# Altium schematic sheet RH850_F1L_revB.SchDoc(RH850_F1L_revB)
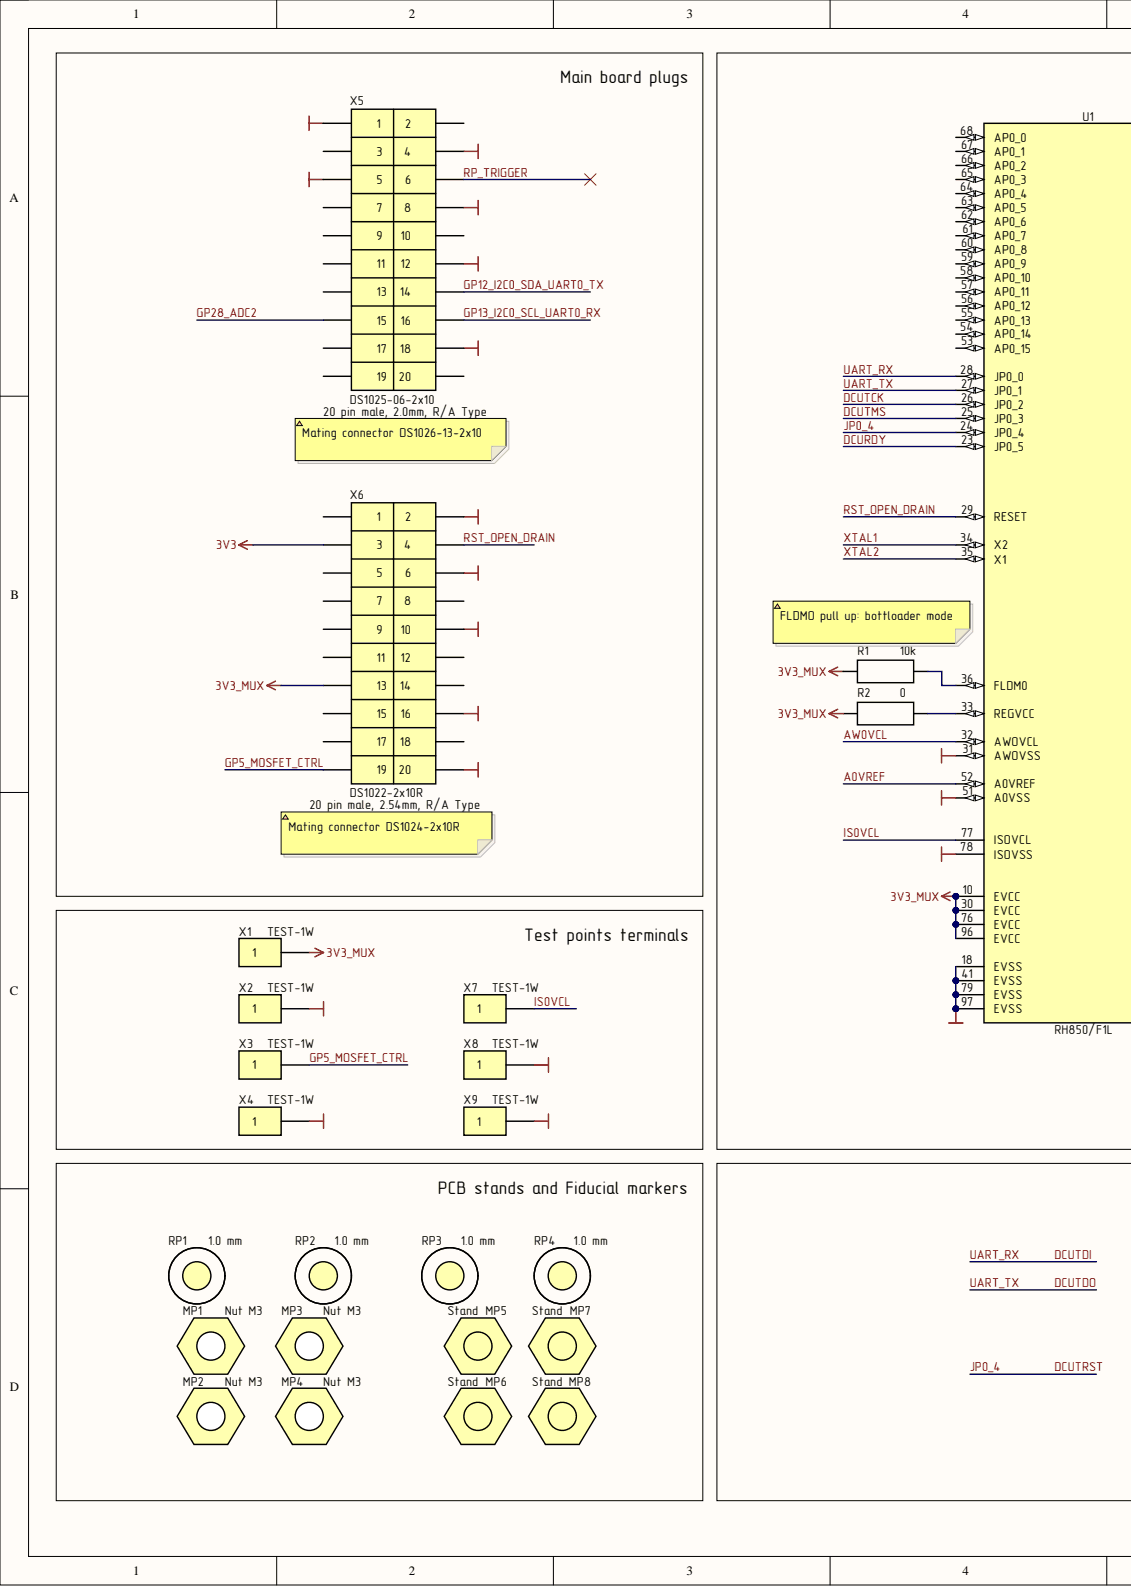
[diagram p0: RH850_F1L_revB.SchDoc, left]
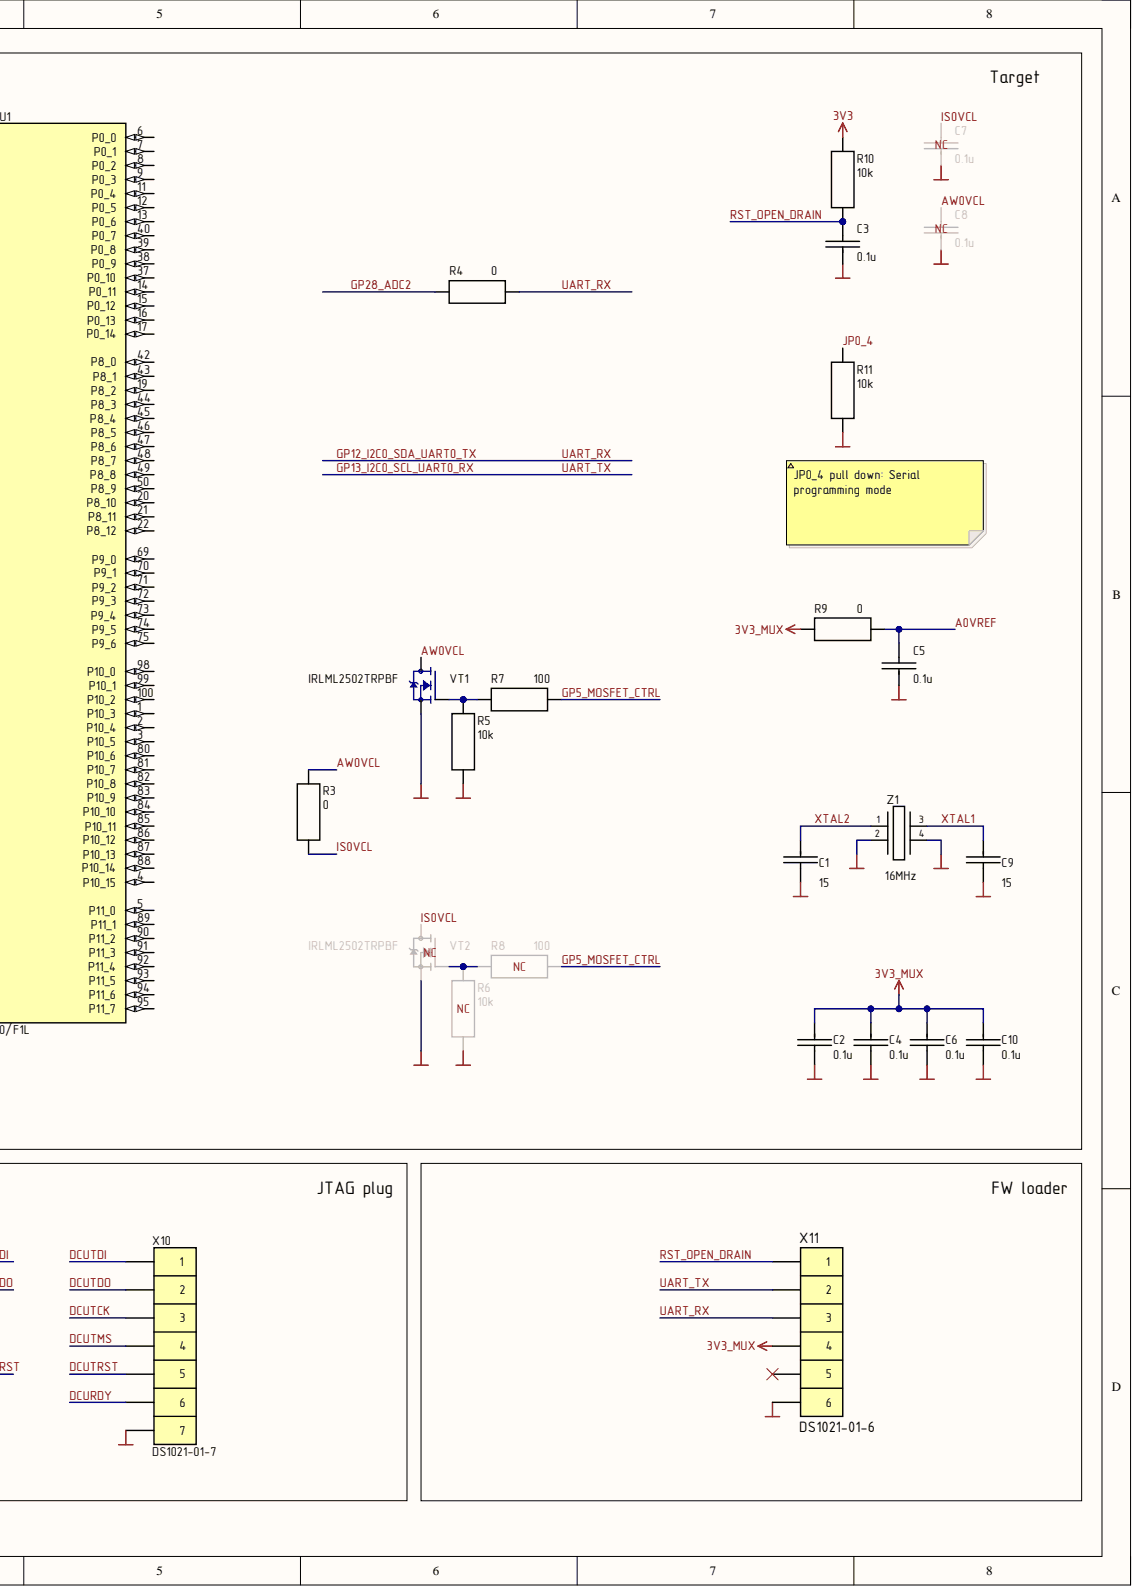
[diagram p1: RH850_F1L_revB.SchDoc, right]

## Parts
C1  value 15  [CH0603C]  (hidden comment: Ceramic capacitor, 0603, 15, ±10%, 100V, C0G, -55...+125°C)
C2  value 0.1u  [CH0603C]  (hidden comment: Ceramic capacitor, 0603, 0.1u, ±10%, 50V, X7R, -55...+125°C)
C3  value 0.1u  [CH0603C]  (hidden comment: Ceramic capacitor, 0603, 0.1u, ±10%, 50V, X7R, -55...+125°C)
C4  value 0.1u  [CH0603C]  (hidden comment: Ceramic capacitor, 0603, 0.1u, ±10%, 50V, X7R, -55...+125°C)
C5  value 0.1u  [CH0603C]  (hidden comment: Ceramic capacitor, 0603, 0.1u, ±10%, 50V, X7R, -55...+125°C)
C6  value 0.1u  [CH0603C]  (hidden comment: Ceramic capacitor, 0603, 0.1u, ±10%, 50V, X7R, -55...+125°C)
C7  value 0.1u  [CH0603C]  (hidden comment: Ceramic capacitor, 0603, 0.1u, ±10%, 50V, X7R, -55...+125°C)
C8  value 0.1u  [CH0603C]  (hidden comment: Ceramic capacitor, 0603, 0.1u, ±10%, 50V, X7R, -55...+125°C)
C9  value 15  [CH0603C]  (hidden comment: Ceramic capacitor, 0603, 15, ±10%, 100V, C0G, -55...+125°C)
C10  value 0.1u  [CH0603C]  (hidden comment: Ceramic capacitor, 0603, 0.1u, ±10%, 50V, X7R, -55...+125°C)
MP1  Nut M3  [Stand]  (mechanical)
MP2  Nut M3  [Stand]  (mechanical)
MP3  Nut M3  [Stand]  (mechanical)
MP4  Nut M3  [Stand]  (mechanical)
MP5  Stand  [Stand]
MP6  Stand  [Stand]
MP7  Stand  [Stand]
MP8  Stand  [Stand]
R1  value 10k  [CH0603R]  (hidden comment: Resistor thick-film, 0603, 10k, ±5%, 0.1W, -55...+125°C)
R2  value 0  [CH0603R]  (hidden comment: Resistor thick-film, 0603, 0, ±5%, 0.1W, -55...+125°C)
R3  value 0  [CH0603R]  (hidden comment: Resistor thick-film, 0603, 0, ±5%, 0.1W, -55...+125°C)
R4  value 0  [CH0603R]  (hidden comment: Resistor thick-film, 0603, 0, ±5%, 0.1W, -55...+125°C)
R5  value 10k  [CH0603R]  (hidden comment: Resistor thick-film, 0603, 10k, ±5%, 0.1W, -55...+125°C)
R6  value 10k  [CH0603R]  (hidden comment: Resistor thick-film, 0603, 10k, ±5%, 0.1W, -55...+125°C)
R7  value 100  [CH0603R]  (hidden comment: Resistor thick-film, 0603, 100, ±5%, 0.1W, -55...+125°C)
R8  value 100  [CH0603R]  (hidden comment: Resistor thick-film, 0603, 100, ±5%, 0.1W, -55...+125°C)
R9  value 0  [CH0603R]  (hidden comment: Resistor thick-film, 0603, 0, ±5%, 0.1W, -55...+125°C)
R10  value 10k  [CH0603R]  (hidden comment: Resistor thick-film, 0603, 10k, ±5%, 0.1W, -55...+125°C)
R11  value 10k  [CH0603R]  (hidden comment: Resistor thick-film, 0603, 10k, ±5%, 0.1W, -55...+125°C)
RP1  1.0 mm  [REPER-10]  (no BOM)
RP2  1.0 mm  [REPER-10]  (no BOM)
RP3  1.0 mm  [REPER-10]  (no BOM)
RP4  1.0 mm  [REPER-10]  (no BOM)
U1  RH850/F1L  [LQFP100-0.5-13.8X13.8MM]
VT1  IRLML2502TRPBF  [SOT23]
VT2  IRLML2502TRPBF  [SOT23]
X1  PartNumber: TEST-1W  [TEST-1W 1pin MULTICOMP]  (hidden comment: VDD)
X2  PartNumber: TEST-1W  [TEST-1W 1pin MULTICOMP]  (hidden comment: GND)
X3  GP5  PartNumber: TEST-1W  [TEST-1W 1pin MULTICOMP]
X4  PartNumber: TEST-1W  [TEST-1W 1pin MULTICOMP]  (hidden comment: GND)
X5  DS1025-06-2x10  [DS1025-06-2x10]
X6  DS1022-2x10R  [DS1022-2x10R]
X7  PartNumber: TEST-1W  [TEST-1W 1pin MULTICOMP]  (hidden comment: IS0VCl)
X8  PartNumber: TEST-1W  [TEST-1W 1pin MULTICOMP]  (hidden comment: GND)
X9  PartNumber: TEST-1W  [TEST-1W 1pin MULTICOMP]  (hidden comment: GND)
X10  DS1021-01-7  [DS1021-01-7 2.54]
X11  DS1021-01-6  [DS1021-01-6  2.54]
Z1  16MHz  [OSC: 3225]

## Nets
3V3: R10.2 X6.3
3V3_MUX: C2.1 C4.1 C6.1 C10.1 R1.2 R2.2 R9.2 U1.10(EVCC) U1.30(EVCC) U1.76(EVCC) U1.96(EVCC) X1.1 X6.13 X11.4
GND: C1.2 C2.2 C3.1 C4.2 C5.1 C6.2 C7.1 C8.1 C9.2 C10.2 R5.1 R6.1 R11.1 U1.18(EVSS) U1.31(AWOVSS) U1.41(EVSS) U1.51(A0VSS) U1.78(ISOVSS) U1.79(EVSS) U1.97(EVSS) VT1.2 VT2.2 X2.1 X4.1 X5.1 X5.4 X5.5 X5.8 X5.12 X5.18 X6.2 X6.6 X6.10 X6.16 X6.20 X8.1 X9.1 X10.7 X11.6 Z1.2 Z1.4
A0VREF: C5.2 R9.1 U1.52(A0VREF)
AW0VCL: C8.2 R3.2 U1.32(AWOVCL) VT1.3
DCURDY: U1.23(JP0_5) X10.6
DCUTCK: U1.26(JP0_2) X10.3
DCUTDI: R4.1 U1.28(JP0_0) X5.14 X10.1 X11.3
DCUTDO: U1.27(JP0_1) X5.16 X10.2 X11.2
DCUTMS: U1.25(JP0_3) X10.4
DCUTRST: R11.2 U1.24(JP0_4) X10.5
GP5_MOSFET_CTRL: R7.1 R8.1 X3.1 X6.19
GP28_ADC2: R4.2 X5.15
IS0VCL: C7.2 R3.1 U1.77(ISOVCL) VT2.3 X7.1
RP_TRIGGER: X5.6
RST_OPEN_DRAIN: C3.2 R10.1 U1.29(RESET) X6.4 X11.1
XTAL1: C9.1 U1.34(X2) Z1.3
XTAL2: C1.1 U1.35(X1) Z1.1
NetR1_1: R1.1 U1.36(FLDM0)
NetR2_1: R2.1 U1.33(REGVCC)
NetR5_2: R5.2 R7.2 VT1.1
NetR6_2: R6.2 R8.2 VT2.1

Not connected: MP5 1; MP6 1; MP7 1; MP8 1; RP1 1; RP2 1; RP3 1; RP4 1; U1 1(P10_3) 2(P10_4) 3(P10_5) 4(P10_15) 5(P11_0) 6(P0_0) 7(P0_1) 8(P0_2) 9(P0_3) 11(P0_4) 12(P0_5) 13(P0_6) 14(P0_11) 15(P0_12) 16(P0_13) 17(P0_14) 19(P8_2) 20(P8_10) 21(P8_11) 22(P8_12) 37(P0_10) 38(P0_9) 39(P0_8) 40(P0_7) 42(P8_0) 43(P8_1) 44(P8_3) 45(P8_4) 46(P8_5) 47(P8_6) 48(P8_7) 49(P8_8) 50(P8_9) 53(AP0_15) 54(AP0_14) 55(AP0_13) 56(AP0_12) 57(AP0_11) 58(AP0_10) 59(AP0_9) 60(AP0_8) 61(AP0_7) 62(AP0_6) 63(AP0_5) 64(AP0_4) 65(AP0_3) 66(AP0_2) 67(AP0_1) 68(AP0_0) 69(P9_0) 70(P9_1) 71(P9_2) 72(P9_3) 73(P9_4) 74(P9_5) 75(P9_6) 80(P10_6) 81(P10_7) 82(P10_8) 83(P10_9) 84(P10_10) 85(P10_11) 86(P10_12) 87(P10_13) 88(P10_14) 89(P11_1) 90(P11_2) 91(P11_3) 92(P11_4) 93(P11_5) 94(P11_6) 95(P11_7) 98(P10_0) 99(P10_1) 100(P10_2); X5 2 3 7 9 10 11 13 17 19 20; X6 1 5 7 8 9 11 12 14 15 17 18; X11 5

## Library data of the parts
C1 C9 = Capacitor: Manufacturer: Murata; PartNumber: GRM1885C2A150J; Type: SMD; Component Kind: Standard; ComponentType: Ceramic capacitor; Description/Value: Ceramic capacitor, 0603, 15, ±10%, 100V, C0G, -55...+125°C; HelpURL: ?; Library Name: Common Lib.SchLib; Package: 0603; Temperature: -55...+125°C; Tolerance: ±10%; TypeDielectric: C0G; Voltage: 100V
C2-C8 C10 = Capacitor: Manufacturer: Murata; PartNumber: GRM188R71H104K; Type: SMD; Component Kind: Standard; ComponentType: Ceramic capacitor; Description/Value: Ceramic capacitor, 0603, 0.1u, ±10%, 50V, X7R, -55...+125°C; HelpURL: ?; Library Name: Common Lib.SchLib; Package: 0603; Temperature: -55...+125°C; Tolerance: ±10%; TypeDielectric: X7R; Voltage: 50V
MP1-MP4 = nut: PartNumber: Nut M3 DIN 934; Description/Value: Hexagon Nut M3 DIN 934; Library Name: Common Lib.SchLib
MP5-MP8 = stand: PartNumber: PCHSN-10; Description/Value: PCB brass stand, M3, 10mm; Component Kind: Standard; Library Name: Common Lib.SchLib
R1 R5 R6 R10 R11 = Resistor: Manufacturer: Yageo; PartNumber: RC0603JR-0710KL; Component Kind: Standard; ComponentType: Resistor thick-film; Description/Value: Resistor thick-film, 0603, 10k, ±5%, 0.1W, -55...+125°C; HelpURL: https://datasheet.lcsc.com/lcsc/2009261810_YAGEO-RL0805FR-070R33L_C724024.pdf; Library Name: Common Lib.SchLib; Package: 0603; Power: 0.1W; Temperature: -55...+125°C; Tolerance: ±5%; Type: SMD
R2-R4 R9 = Resistor: Manufacturer: Yageo; PartNumber: RC0603JR-070RL; Component Kind: Standard; ComponentType: Resistor thick-film; Description/Value: Resistor thick-film, 0603, 0, ±5%, 0.1W, -55...+125°C; HelpURL: https://datasheet.lcsc.com/lcsc/2009261810_YAGEO-RL0805FR-070R33L_C724024.pdf; Library Name: Common Lib.SchLib; Package: 0603; Power: 0.1W; Temperature: -55...+125°C; Tolerance: ±5%; Type: SMD
R7 R8 = Resistor: Manufacturer: Yageo; PartNumber: RC0603JR-07100RL; Component Kind: Standard; ComponentType: Resistor thick-film; Description/Value: Resistor thick-film, 0603, 100, ±5%, 0.1W, -55...+125°C; HelpURL: https://datasheet.lcsc.com/lcsc/2009261810_YAGEO-RL0805FR-070R33L_C724024.pdf; Library Name: Common Lib.SchLib; Package: 0603; Power: 0.1W; Temperature: -55...+125°C; Tolerance: ±5%; Type: SMD
RP1-RP4 = CMP-00005-2: Design Item ID: REPER; Source: Common Lib.SchLib; Library Name: Positive Labs Server
U1 = CMP-00048-2: Renesas microcontroller RH850/F1L in LQFP100 case; PartNumber: R7F7010233; Description/Value: RH850/F1L Microcontroller IC 32-Bit Single-Core 100-LFQFP (14x14); Component Kind: Standard; Design Item ID: RH850/F1L; Source: Common Lib.SchLib; Library Name: Positive Labs Server
VT1 VT2 = MOSFET N: RoHS Compliant | Industry-leading quality | Fast Switching | Low Profile _less than 1.1mm_ | SOT-23 Footprint; Description/Value: N-MOSFET Transistor, 20V, 4.2A, SOT-23-23; Component Kind: Standard; Library Name: Common Lib.SchLib; Package: SOT-23; PartNumber: IRLML2502TRPBF
X1-X4 X7-X9 = 1-Connector: Description/Value: PCB Test Point Through Hole Mount; Component Kind: Standard; Library Name: Common Lib.SchLib
X5 = 20-Connector: Description/Value: PLD Connector 20 pin, 2.0mm, Male, DS1025-06-2x10; Component Kind: Standard; ComponentKind: PLD Connector 20 pin, 2.0mm, Male; Library Name: Common Lib.SchLib; PartNumber: DS1025-06-2x10
X6 = 20-Connector: Description/Value: PLD Connector 20 pin, 2.54mm, Male, DS1022-2x10R; Component Kind: Standard; ComponentKind: PLD Connector 20 pin, 2.54mm, Male; Library Name: Common Lib.SchLib; PartNumber: DS1022-2x10R
X10 = 7-Connector: Description/Value: PLS Connector 7 pin, 2.54mm, Male; Component Kind: Standard; ComponentKind: PLS Connector 7 pin, 2.54mm, Male; Library Name: Common Lib.SchLib; PartNumber: DS1021-01-7
X11 = 6-Connector: Description/Value: PLS Connector 6 pins, 2.54mm, Male; Component Kind: Standard; Library Name: Common Lib.SchLib; PartNumber: DS1021-01-6
Z1 = Crystal_3225_25MHz: PartNumber: KSE-7U16000M9Z143ZA3; Description/Value: Crystal, SMD3225C4, 16MHz; Component Kind: Standard; Library Name: Common Lib.SchLib
